# Altium schematic sheet Power.SchDoc(Power)
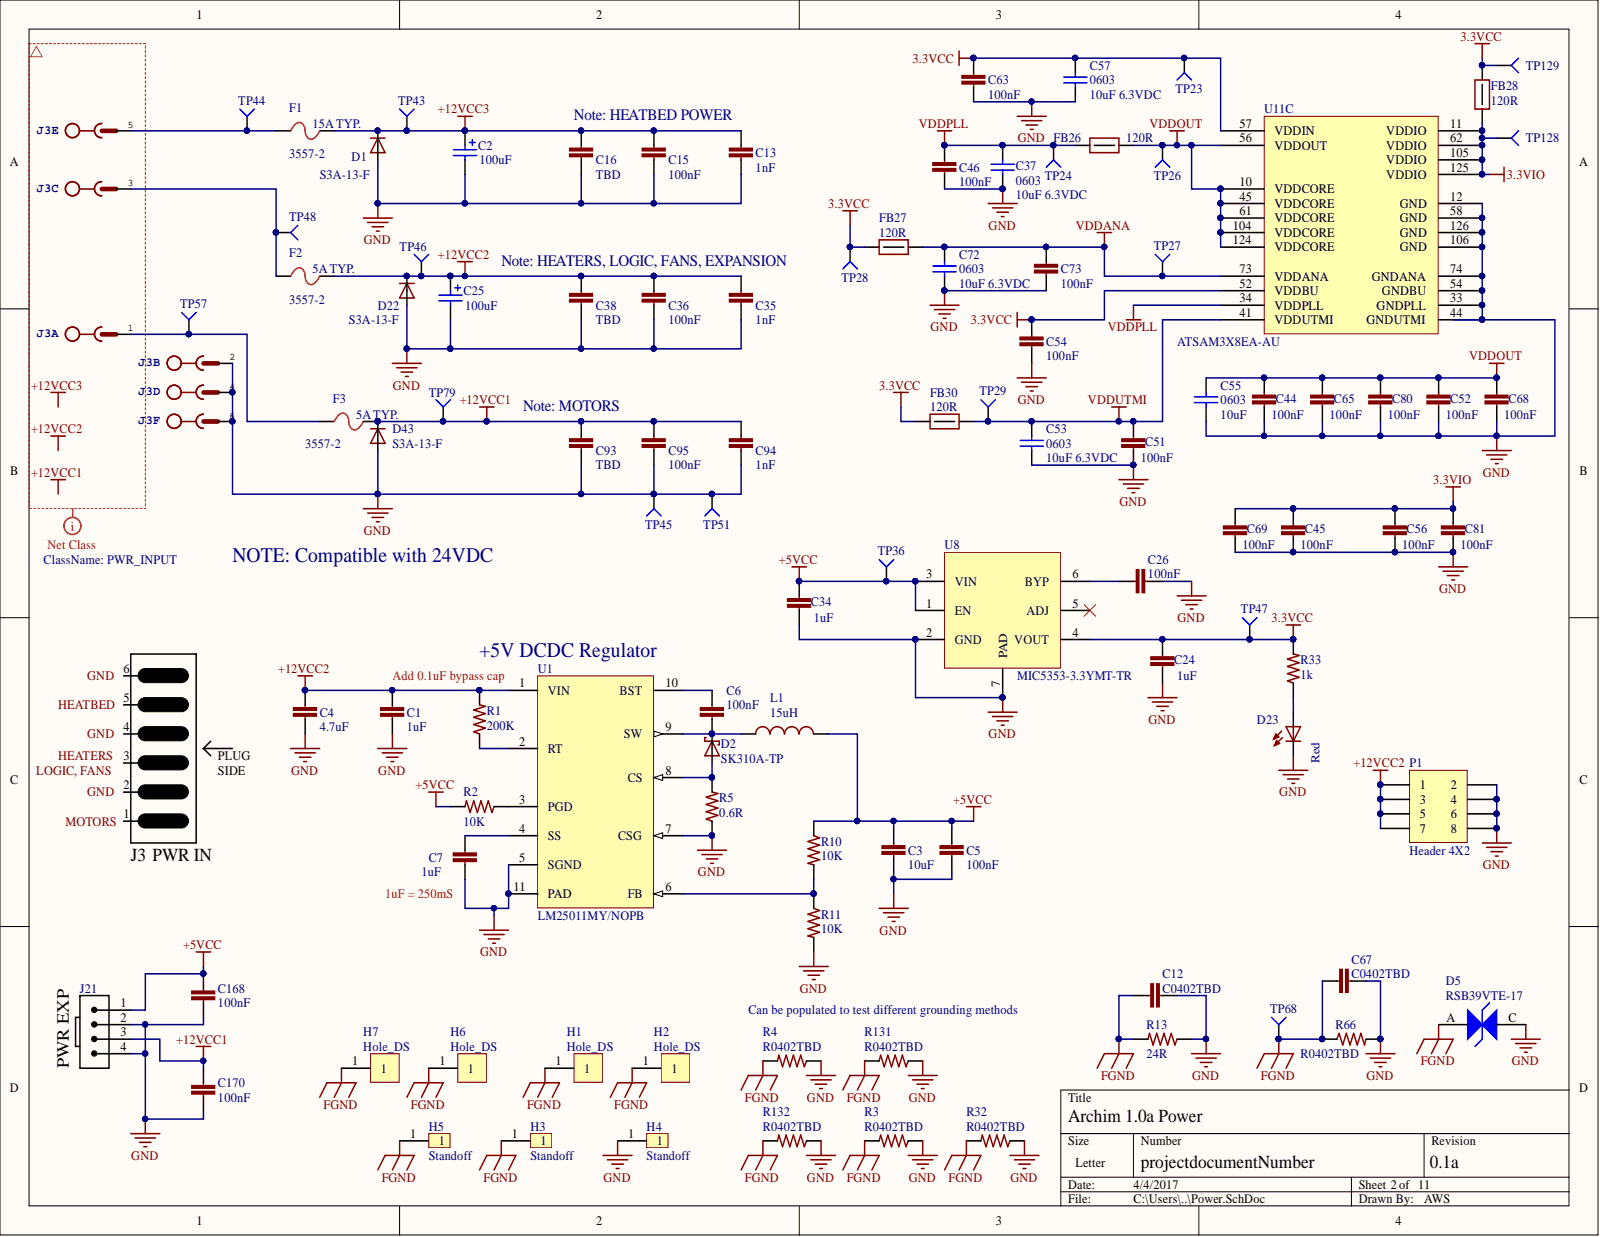
[diagram p0: Power.SchDoc]

## Parts
C1  1uF  [C0805]
C2  value 100uF  [CAP-ELEC-063063077]  (hidden comment: UWT1V101MCL1GS)
C3  10uF  [C0805]
C4  4.7uF  [C1206]
C5  100nF  [C0402]
C6  100nF  [C0603]
C7  1uF  [C0402]
C12  C0402TBD  [C0402]
C13  1nF  [C0402]
C15  100nF  [C0603]
C16  TBD  [C1206]
C24  1uF  [C0402]
C25  value 100uF  [CAP-ELEC-063063077]  (hidden comment: UWT1V101MCL1GS)
C26  100nF  [C0402]
C34  1uF  [C0402]
C35  1nF  [C0402]
C36  100nF  [C0603]
C37  0603  value 10uF 6.3VDC  [C0603]
C38  TBD  [C1206]
C44  100nF  [C0402]
C45  100nF  [C0402]
C46  100nF  [C0402]
C51  100nF  [C0402]
C52  100nF  [C0402]
C53  0603  value 10uF 6.3VDC  [C0603]
C54  100nF  [C0402]
C55  0603  value 10uF  [C0603]
C56  100nF  [C0402]
C57  0603  value 10uF 6.3VDC  [C0603]
C63  100nF  [C0402]
C65  100nF  [C0402]
C67  C0402TBD  [C0402]
C68  100nF  [C0402]
C69  100nF  [C0402]
C72  0603  value 10uF 6.3VDC  [C0603]
C73  100nF  [C0402]
C80  100nF  [C0402]
C81  100nF  [C0402]
C93  TBD  [C1206]
C94  1nF  [C0402]
C95  100nF  [C0603]
C168  100nF  [C0402]
C170  100nF  [C0402]
D1  S3A-13-F  [DO-214AB]
D2  SK310A-TP  [SMA-SM]
D5  RSB39VTE-17  [SOD323-R]
D22  S3A-13-F  [DO-214AB]
D23  Red  [LED2012120]
D43  S3A-13-F  [DO-214AB]
F1  3557-2  [3557]
F2  3557-2  [3557]
F3  3557-2  [3557]
FB26  120R  [FB0603]
FB27  120R  [FB0603]
FB28  120R  [FB0603]
FB30  120R  [FB0603]
H1  Hole_DS  [Wave_hole_3x7-doubleSided]  (no BOM)
H2  Hole_DS  [Wave_hole_3x7-doubleSided]  (no BOM)
H3  Standoff  [Standoff3mmTopConn]  (no BOM)
H4  Standoff  [Standoff3mmTopConn]  (no BOM)
H5  Standoff  [Standoff3mmTopConn]  (no BOM)
H6  Hole_DS  [Wave_hole_3x7-doubleSided]  (no BOM)
H7  Hole_DS  [Wave_hole_3x7-doubleSided]  (no BOM)
J3  MSTBH6  [MSTBH6]  (value not drawn)  (parts A,B,C,D,E,F)
J21  Molex 0705430003  [4POL254]  (value not drawn)
L1  15uH  [XAL50xx-153]
P1  Header 4X2  [HDR2X4]
R1  200K  [R0402]
R2  10K  [R0402]
R3  R0402TBD  [R0402]
R4  R0402TBD  [R0402]
R5  0.6R  [2512R-0.55]
R10  10K  [R0402]
R11  10K  [R0402]
R13  24R  [R0402]
R32  R0402TBD  [R0402]
R33  1k  [R0402]
R66  R0402TBD  [R0402]
R131  R0402TBD  [R0402]
R132  R0402TBD  [R0402]
TP23  TP4  [TP-2]  (value not drawn)  (no BOM)
TP24  TP4  [TP-2]  (value not drawn)  (no BOM)
TP26  TP4  [TP-2]  (value not drawn)  (no BOM)
TP27  TP4  [TP-2]  (value not drawn)  (no BOM)
TP28  TP4  [TP-2]  (value not drawn)  (no BOM)
TP29  TP4  [TP-2]  (value not drawn)  (no BOM)
TP36  TP4  [TP-2]  (value not drawn)  (no BOM)
TP43  TP4  [TP-2]  (no BOM)
TP44  TP4  [TP-2]  (no BOM)
TP45  TP4  [TP-2]  (no BOM)
TP46  TP4  [TP-2]  (no BOM)
TP47  TP4  [TP-2]  (no BOM)
TP48  TP4  [TP-2]  (no BOM)
TP51  TP4  [TP-2]  (value not drawn)  (no BOM)
TP57  TP4  [TP-2]  (value not drawn)  (no BOM)
TP68  TP4  [TP-2]  (value not drawn)  (no BOM)
TP79  TP4  [TP-2]  (value not drawn)  (no BOM)
TP128  TP4  [TP-2]  (value not drawn)  (no BOM)
TP129  TP4  [TP-2]  (value not drawn)  (no BOM)
U1  LM25011MY/NOPB  [VSSOP10]
U8  MIC5353-3.3YMT-TR  [TDFN1616-6LD-PL-1]
U11  ATSAM3X8EA-AU  [LQFP144_N]  (part C)

## Nets
3.3VCC: C24.1 C54.1 C57.2 C63.1 FB27.1 FB28.2 FB30.1 R33.2 TP23.1 TP28.1 TP47.1 TP129.1 U8.4(VOUT) U11.52(VDDBU) U11.57(VDDIN)
3.3VIO: C45.1 C56.1 C69.1 C81.1 FB28.1 TP128.1 U11.11(VDDIO) U11.62(VDDIO) U11.105(VDDIO) U11.125(VDDIO)
+5VCC: C3.1 C5.2 C34.1 C168.2 J21.1 L1.2 R2.2 R10.1 TP36.1 U8.1(EN) U8.3(VIN)
+12VCC1: C93.1 C94.1 C95.1 C170.2 D43.C F3.2 J21.3 TP79.1
+12VCC2: C1.1 C4.1 C25.1 C35.1 C36.1 C38.1 D22.C F2.2 P1.1 P1.3 P1.5 P1.7 R1.2 TP46.1 U1.1(VIN)
+12VCC3: C2.1 C13.1 C15.1 C16.1 D1.C F1.2 TP43.1
FGND: C12.1 C67.1 D5.A H1.1 H2.1 H3.1 H5.1 H6.1 H7.1 R3.2 R4.2 R13.2 R32.2 R66.1 R131.2 R132.2 TP68.1
GND: C1.2 C2.2 C3.2 C4.2 C5.1 C7.2 C12.2 C13.2 C15.2 C16.2 C24.2 C25.2 C26.2 C34.2 C35.2 C36.2 C37.1 C38.2 C44.2 C45.2 C46.2 C51.2 C52.2 C53.1 C54.2 C55.1 C56.2 C57.1 C63.2 C65.2 C67.2 C68.2 C69.2 C72.1 C73.2 C80.2 C81.2 C93.2 C94.2 C95.2 C168.1 C170.1 D1.A D5.C D22.A D23.C D43.A H4.1 J3.2 J3.4 J3.6 J21.2 J21.4 P1.2 P1.4 P1.6 P1.8 R3.1 R4.1 R5.1 R11.2 R13.1 R32.1 R66.2 R131.1 R132.1 TP45.1 TP51.1 U1.5(SGND) U1.7(CSG) U1.11(PAD) U8.2(GND) U8.7(PAD) U11.12(GND) U11.33(GNDPLL) U11.44(GNDUTMI) U11.54(GNDBU) U11.58(GND) U11.74(GNDANA) U11.106(GND) U11.126(GND)
VDDANA: C72.2 C73.1 FB27.2 TP27.1 U11.73(VDDANA)
VDDOUT: C44.1 C52.1 C55.2 C65.1 C68.1 C80.1 FB26.2 TP26.1 U11.10(VDDCORE) U11.45(VDDCORE) U11.56(VDDOUT) U11.61(VDDCORE) U11.104(VDDCORE) U11.124(VDDCORE)
VDDPLL: C37.2 C46.1 FB26.1 TP24.1 U11.34(VDDPLL)
VDDUTMI: C51.1 C53.2 FB30.2 TP29.1 U11.41(VDDUTMI)
NetC6_1: C6.1 U1.10(BST)
NetC6_2: C6.2 D2.C L1.1 U1.9(SW)
NetC7_1: C7.1 U1.4(SS)
NetC26_1: C26.1 U8.6(BYP)
NetD2_A: D2.A R5.2 U1.8(CS)
NetD23_A: D23.A R33.1
NetF1_1: F1.1 J3.5 TP44.1
NetF2_1: F2.1 J3.3 TP48.1
NetF3_1: F3.1 J3.1 TP57.1
NetR1_1: R1.1 U1.2(RT)
NetR2_1: R2.1 U1.3(PGD)
NetR10_2: R10.2 R11.1 U1.6(FB)
N00363:

Not connected: U8 5(ADJ)

## Library data of the parts
C1 = GRM21BR71H105KA12L: CAP CER 0805 1uF 50V 10% X7R; PART DESCRIPTION: 1µF ±10% 50V Ceramic Capacitor X7R 0805 (2012 Metric); PART: 1uF 50V X7R 0805; MANUFACTURER: Murata; VALUE: 1uF; TOLERANCE: 10%; Simulation: Model Name; Signal Integrity: Model Name; MANUFACTURER #: GRM21BR71H105KA12L; Library Name: 3Delight.SCHLIB; EE_SPEC: 50V; DISTRIBUTOR #: 490-4736-2-ND; DISTRIBUTOR: Digikey; Component Kind: Standard
C2 C25 = UWT1V101MCL1GS: CAP ALUM 100UF 35V 20% SMD; PART DESCRIPTION: 100µF 35V Aluminum Capacitors Radial, Can - SMD 1000 Hrs @ 105°C; PART: 100uF 35V SMD Al-El; MANUFACTURER: Nichicon; TOLERANCE: 20%; Simulation: CAP; Signal Integrity: Cap Pol; MANUFACTURER #: UWT1V101MCL1GS; Library Name: 3Delight.SCHLIB; EE_SPEC: 35V; DISTRIBUTOR #: 493-2203-2-ND; DISTRIBUTOR: Digikey; Component Kind: Standard
C3 = C0805C106K8PACTU: CAP CER 0805 10uF 10V 10% X5R; PART DESCRIPTION: 10µF ±10% 10V Ceramic Capacitor X5R 0805 (2012 Metric); PART: 10uF 10V X5R 0805; MANUFACTURER: Kemet; VALUE: 10uF; TOLERANCE: 10%; Simulation: Model Name; Signal Integrity: Model Name; MANUFACTURER #: C0805C106K8PACTU; Library Name: 3Delight.SCHLIB; EE_SPEC: 10V; DISTRIBUTOR #: 399-4925-2-ND; DISTRIBUTOR: Digikey; Component Kind: Standard
C4 = C1206C475K5PACTU: CAP CER 1206 4.7uF 50V 10% X5R; PART DESCRIPTION: 4.7µF ±10% 50V Ceramic Capacitor X5R 1206 (3216 Metric); PART: 4.7uF 50V X5R 1206; MANUFACTURER: Kemet; VALUE: 4.7uF; TOLERANCE: 10%; Simulation: Model Name; Signal Integrity: Model Name; MANUFACTURER #: C1206C475K5PACTU; Library Name: 3Delight.SCHLIB; EE_SPEC: 50V; DISTRIBUTOR #: 399-5507-2-ND; DISTRIBUTOR: Digikey; Component Kind: Standard
C5 C26 C44-C46 C51 C52 C54 C56 C63 C65 C68 C69 C73 C80 C81 C168 C170 = GRM155R71C104KA88D: CAP CER 0402 100nF 16V 10% X7R; PART DESCRIPTION: 0.10µF ±10% 16V Ceramic Capacitor X7R 0402 (1005 Metric); PART: 0.1uF 16V X7R 0402; MANUFACTURER: Murata; VALUE: 100nF; TOLERANCE: 10%; Simulation: Model Name; Signal Integrity: Model Name; MANUFACTURER #: GRM155R71C104KA88D; Library Name: 3Delight.SCHLIB; EE_SPEC: 16V; DISTRIBUTOR #: 490-3261-2-ND; DISTRIBUTOR: Digikey; Component Kind: Standard
C6 C15 C36 C95 = C0603C104K5RACTU: CAP CER 0603 100nF 50V 10% X7R; PART DESCRIPTION: 0.10µF ±10% 50V Ceramic Capacitor X7R 0603 (1608 Metric); PART: 100nF 50V X7R 0603; MANUFACTURER: Kemet; VALUE: 100nF; TOLERANCE: 10%; Simulation: Model Name; Signal Integrity: Model Name; MANUFACTURER #: C0603C104K5RACTU; Library Name: 3Delight.SCHLIB; EE_SPEC: 50V; DISTRIBUTOR #: 399-5089-2-ND; DISTRIBUTOR: Digikey; Component Kind: Standard
C7 C24 C34 = GRM155R60J105KE19D: CAP CER 0402 1uF 6.3V 10% X5R; PART DESCRIPTION: 1µF ±10% 6.3V Ceramic Capacitor X5R 0402 (1005 Metric); PART: 1uF 6.3V X5R 0402; MANUFACTURER: Murata; VALUE: 1uF; TOLERANCE: 10%; Simulation: Model Name; Signal Integrity: Model Name; MANUFACTURER #: GRM155R60J105KE19D; Library Name: 3Delight.SCHLIB; EE_SPEC: 6.3V; DISTRIBUTOR #: 490-1320-2-ND; DISTRIBUTOR: Digikey; Component Kind: Standard
C12 C67 = C0402TBD: Capacitor Non Polarized; MANUFACTURER #: DNI-C0402TBD; VALUE: C0402TBD; Simulation: Model Name; Signal Integrity: Model Name; Library Name: 3Delight.SCHLIB; DNI: DNI; Component Kind: Standard
C13 C35 C94 = CAP 1nF 50V 0402 X7R: 1000pF 50V Ceramic Capacitor X7R 0402 (1005 Metric) 0.039" L x 0.020" W (1.00mm x 0.50mm); PART DESCRIPTION: 1000pF ±10% 50V Ceramic Capacitor X7R 0402 (1005 Metric); PART: 1nF 50V X7R 0402; MANUFACTURER #: GRM155R71H102KA01D; MANUFACTURER: Murata; TOLERANCE: 10%; VALUE: 1nF; Library Name: 3Delight.SCHLIB; EE_SPEC: 50V; DISTRIBUTOR #: 490-1303-2-ND; DISTRIBUTOR: Digikey; Component Kind: Standard
C16 C38 C93 = CL31A106KBHNNNE: CAP CER 10UF 50V 10% X5R 1206; PART DESCRIPTION: 10µF ±10% 50V Ceramic Capacitor X5R 1206 (3216 Metric); PART: 10uF 50V X5R 1206; MANUFACTURER: Samsung; VALUE: TBD; TOLERANCE: 10%; Simulation: Model Name; Signal Integrity: Model Name; MANUFACTURER #: CL31A106KBHNNNE; Library Name: Tigershark3D.SchLib; EE_SPEC: 50V; DNI: DNI; DISTRIBUTOR #: 1276-2876-2-ND; DISTRIBUTOR: Digikey; Component Kind: Standard
C37 C53 C57 C72 = C1608JB0J106K080AB: CAP CER 10UF 6.3V 10% JB 0603; PART: 10µF ±10% 6.3V Ceramic Capacitor JB 0603 (1608 Metric); MANUFACTURER: TDK; VALUE: 10uF 6.3VDC; EE_SPEC: 6.3VDC; TOLERANCE: 10%; Simulation: CAP; Signal Integrity: Cap Semi; PART DESCRIPTION: CAP CER 10UF 6.3V 10% JB 0603; MANUFACTURER #: C1608JB0J106K080AB; Library Name: Tigershark3D.SchLib; DISTRIBUTOR #: 445-11185-1-ND; DISTRIBUTOR: Digikey; Component Kind: Standard
C55 = C1608JB0J106K080AB: CAP CER 10UF 6.3V 10% JB 0603; PART: 10µF ±10% 6.3V Ceramic Capacitor JB 0603 (1608 Metric); MANUFACTURER: TDK; EE_SPEC: 6.3VDC; TOLERANCE: 10%; Simulation: CAP; Signal Integrity: Cap Semi; PART DESCRIPTION: CAP CER 10UF 6.3V 10% JB 0603; MANUFACTURER #: C1608JB0J106K080AB; Library Name: Tigershark3D.SchLib; DISTRIBUTOR #: 445-11185-1-ND; DISTRIBUTOR: Digikey; Component Kind: Standard
D1 D22 D43 = S3A-13-F: DIODE DO-214AB; PART DESCRIPTION: Diode Standard 50V 3A Surface Mount SMC; PART: Diode 50V 3A; MANUFACTURER: Diodes Incorporated; TOLERANCE: -NA-; EE_SPEC: 50V 3A; VALUE: S3A-13-F; MANUFACTURER #: S3A-13-F; Library Name: 3Delight.SCHLIB; DISTRIBUTOR #: S3A-FDITR-ND; DISTRIBUTOR: Digikey; Component Kind: Standard
D2 = SK310A: DIODE Schottky 3A SMA; PART DESCRIPTION: Diode Schottky 100V 3A Surface Mount DO-214AC (HSMA); PART: Schottky Diode 100V 3A; MANUFACTURER: MCC; VALUE: -NA-; EE_SPEC: 100V 3A; TOLERANCE: -NA-; MANUFACTURER #: SK310A; DISTRIBUTOR #: SK310A-TPTR-ND; DISTRIBUTOR: Digikey; Component Kind: Standard; Library Name: Archim_0.4.SCHLIB
D5 = RSB39VTE-17: DIODE TVS; PART DESCRIPTION: TVS DIODE 30VWM SOD323; MANUFACTURER: Rohm; VALUE: 30V; TOLERANCE: -NA-; EE_SPEC: 30Vso 30pF; PART: TVS DIODE 30VWM SOD323; MANUFACTURER #: RSB39VTE-17; Library Name: 3Delight.SCHLIB; DISTRIBUTOR #: RSB39VTE-17TR-ND; DISTRIBUTOR: Digikey; Component Kind: Standard
D23 = 5988170107F: LED 0805 Green; PART DESCRIPTION: Red 635nm LED Indication - Discrete 2.2V 0805 (2012 Metric); PART: LED Red 0805; MANUFACTURER #: 5988110107F; MANUFACTURER: Dialight; TOLERANCE: -NA-; EE_SPEC: Vf=2.2V; VALUE: Red; Library Name: 3Delight.SCHLIB; DISTRIBUTOR #: 350-2038-2-ND; DISTRIBUTOR: Digikey; Component Kind: Standard
F1-F3 = 3557-2: FUSE PTH ATO; PART DESCRIPTION: Fuse Block 30A 500V 1 Circuit Blade PCB; PART: Fuse Holder; MANUFACTURER: Keystone; VALUE: -NA-; EE_SPEC: 500V; TOLERANCE: -NA-; MANUFACTURER #: 3557-2; Library Name: 3Delight.SCHLIB; DISTRIBUTOR #: 36-3557-2-ND; DISTRIBUTOR: Digikey; Component Kind: Standard
FB26-FB28 FB30 = FERRITE 120R 3A 0603: FERRITE BEAD 120 OHM 0603 1LN; MANUFACTURER #: CIS10P121AC; MANUFACTURER2#: 490-5254-2-ND; MANUFACTURER: Samsung; VALUE: 120Rfb; TOLERANCE: -NA-; EE_SPEC: 3A; PART DESCRIPTION: FERRITE BEAD 120 OHM 0603 1LN; PART: FERRITE 120R 3A 0603; Library Name: 3Delight.SCHLIB; DISTRIBUTOR #: 1276-6351-2-ND; DISTRIBUTOR: Digikey; Component Kind: Standard
H1 H2 H6 H7 = Hole_DS: MANUFACTURER #: NOTAPART-Hole; Library Name: Archim_0.4.SCHLIB
H3-H5 = Standoff3TopConn: Mechanical - Top connect; MANUFACTURER #: NOTAPART-Hole; Library Name: 3Delight.SCHLIB
J3 = MSTBH6: PHOENIX; PART DESCRIPTION: 6 Position Terminal Block Header, Male Pins, Shrouded (4 Side) 0.200" (5.08mm) 90°, Right Angle Through Hole; PART: 6 Pin Conn RA; MANUFACTURER #: 39532-1006; MANUFACTURER: Molex; VALUE: HDR6; TOLERANCE: -NA-; Library Name: 3Delight.SCHLIB; EE_SPEC: -NA-; DISTRIBUTOR #: WM7849-ND; DISTRIBUTOR: Digikey; Component Kind: Standard
J21 = Molex 0705430003 Alt_1: Molex 4 Pin Clip Connector; Component Kind: Standard; Library Name: Archim.SCHLIB
L1 = XAL5050-153MEB: IND 15uH 3.9A 20%; PART: 0.1uF 16V X7R 0402; MANUFACTURER: Coilcraft; EE_SPEC: Isat=3.7 Irms=3.4; VALUE: 15uH; TOLERANCE: 20%; MANUFACTURER #: XAL5050-153MEB; Library Name: 3Delight.SCHLIB; DISTRIBUTOR #: XAL5050-153MEB; DISTRIBUTOR: Coilcraft; Component Kind: Standard
P1 = Header 4X2: Header, 4-Pin, Dual row; PART DESCRIPTION: Board-To-Board Connector, 2.54 mm, 8 Contacts, Header, 2213S Series, Through Hole, 2 Rows; PART: CONN 8Pin 2Row 0.1"; MANUFACTURER #: 2213S-08G; MANUFACTURER: Multicomp; VALUE: HDR8; TOLERANCE: -NA-; Signal Integrity: Connector; Library Name: Miscellaneous Connectors.IntLib; EE_SPEC: -NA-; DISTRIBUTOR #: 08N6790; DISTRIBUTOR: Future; Component Kind: Standard
R1 = CRCW0402200KFKED: RES 0402 200k 63mW 1%; PART DESCRIPTION: 200k Ohm ±1% 0.063W, 1/16W Chip Resistor 0402 (1005 Metric) Automotive AEC-Q200 Thick Film; PART: 200k 1% 0402; MANUFACTURER: Vishay Dale; EE_SPEC: 1/16W; VALUE: 200K; TOLERANCE: 1%; Simulation: Model Name; Signal Integrity: Model Name; MANUFACTURER #: CRCW0402200KFKED; Library Name: 3Delight.SCHLIB; DISTRIBUTOR #: 541-200KLTR-ND; DISTRIBUTOR: Digikey; Component Kind: Standard
R2 R10 R11 = RC0402FR-0710KP: RES SMD 10K OHM 1% 1/16W 0402; PART DESCRIPTION: 10k Ohm ±1% 0.063W, 1/16W Chip Resistor 0402 (1005 Metric) Moisture Resistant Thick Film; PART: 10k 1% 0402; MANUFACTURER: Yageo; VALUE: 10K; TOLERANCE: 1%; Simulation: Model Name; Signal Integrity: Model Name; MANUFACTURER #: RC0402FR-0710KP; Library Name: 3Delight.SCHLIB; EE_SPEC: 1/16W; DISTRIBUTOR #: YAG1249TR-ND; DISTRIBUTOR: Digikey; Component Kind: Standard
R3 R4 R32 R66 R131 R132 = R0402TBD: Resistor; MANUFACTURER #: DNI-R0402TBD; VALUE: R0402TBD; Simulation: Model Name; Signal Integrity: Model Name; Library Name: 3Delight.SCHLIB; DNI: DNI; Component Kind: Standard
R5 = RL2512FK-070R06L: RES 0.06 OHM 1W 1% 2512 SMD; PART DESCRIPTION: 0.06 Ohm ±1% 1W Chip Resistor 2512 (6432 Metric) Automotive AEC-Q200, Current Sense, Moisture Resistant Thick Film; PART: 0.6R 1% 2512; MANUFACTURER: Yageo; VALUE: 0.6R; TOLERANCE: 1%; Simulation: Model Name; Signal Integrity: Model Name; MANUFACTURER #: RL2512FK-070R06L; Library Name: 3Delight.SCHLIB; EE_SPEC: 1W; DISTRIBUTOR #: 311-0.06TTR-ND; DISTRIBUTOR: Digikey; Component Kind: Standard
R13 = CRCW040224R0FKED: Res Thick Film 0402 24 Ohm 1% 0.063W; PART DESCRIPTION: 24 Ohm ±1% 0.063W, 1/16W Chip Resistor 0402 (1005 Metric) Moisture Resistant Thick Film; PART: 24R 1% 0402; MANUFACTURER #: RC0402FR-0724RL; MANUFACTURER: Yageo; EE_SPEC: 1/16W; VALUE: 24R; TOLERANCE: 1%; Simulation: Model Name; Signal Integrity: Model Name; Library Name: 3Delight.SCHLIB; DISTRIBUTOR #: YAG3073TR-ND; DISTRIBUTOR: Digikey; Component Kind: Standard
R33 = RK73H1ETTP1001F: RES 0402 1k 63mW 1%; PART DESCRIPTION: 1k Ohm ±1% 0.063W, 1/16W Chip Resistor 0402 (1005 Metric) Moisture Resistant Thick Film; PART: 1k 1% 0402; MANUFACTURER #: RC0402FR-071KL; MANUFACTURER: Yageo; EE_SPEC: 1/16W; VALUE: 1k; TOLERANCE: 1%; Simulation: Model Name; Signal Integrity: Model Name; Library Name: 3Delight.SCHLIB; DISTRIBUTOR #: 311-1.00KLRTR-ND; DISTRIBUTOR: Digikey; Component Kind: Standard
TP23 TP24 TP26-TP29 TP36 TP43-TP48 TP51 TP57 TP68 TP79 TP128 TP129 = Socket: MANUFACTURER #: NOTAPART-Testpoint; Signal Integrity: Connector; Description: Socket; Library Name: Archim.SCHLIB
U1 = LM25011: REG BUCK; PART DESCRIPTION: Buck Switching Regulator IC Positive Adjustable 2.51V 1 Output 2A 10-TFSOP, 10-MSOP (0.118", 3.00mm Width) Exposed Pad; PART: IC VREG BUCK ADJ 2A 10MSOP; MANUFACTURER: Texas Instruments; TOLERANCE: -NA-; EE_SPEC: 2A 42Vin_max; VALUE: LM25011MY/NOPB; MANUFACTURER #: LM25011MY/NOPB; Library Name: 3Delight.SCHLIB; DISTRIBUTOR #: LM25011MY/NOPBTR-ND; DISTRIBUTOR: Digikey; Component Kind: Standard
U8 = MIC5353-3.3YMT-TR: LDO 3.3V 500mA - low ESR Cout; PART DESCRIPTION: Linear Voltage Regulator IC Positive Fixed 1 Output 3.3V 500mA 6-TMLF® (1.6x1.6); PART: IC REG LDO 3.3V 0.5A 6TMLF; MANUFACTURER: Microchip; VALUE: 3.3V LDO; TOLERANCE: -NA-; MANUFACTURER #: MIC5353-3.3YMT-TR; Library Name: Tigershark3D.SchLib; EE_SPEC: 500mA; DISTRIBUTOR #: 576-3680-2-ND; DISTRIBUTOR: Digikey; Component Kind: Standard
U11 = ATML-ATSAM3X-LQFP-144: Atmel; PART DESCRIPTION: ARM® Cortex®-M3 SAM3X Microcontroller IC 32-Bit 84MHz 512KB (512K x 8) FLASH 144-LQFP (20x20); PART: IC MCU 32BIT 512KB FLASH 144LQFP; VALUE: -NA-; EE_SPEC: 3.3V; TOLERANCE: -NA-; MANUFACTURER #: ATSAM3X8EA-AU; MANUFACTURER: Atmel; DISTRIBUTOR #: ATSAM3X8EA-AU-ND; DISTRIBUTOR: Digikey; Component Kind: Standard
